# Altium schematic sheet Sheet1.SchDoc(Sheet1)
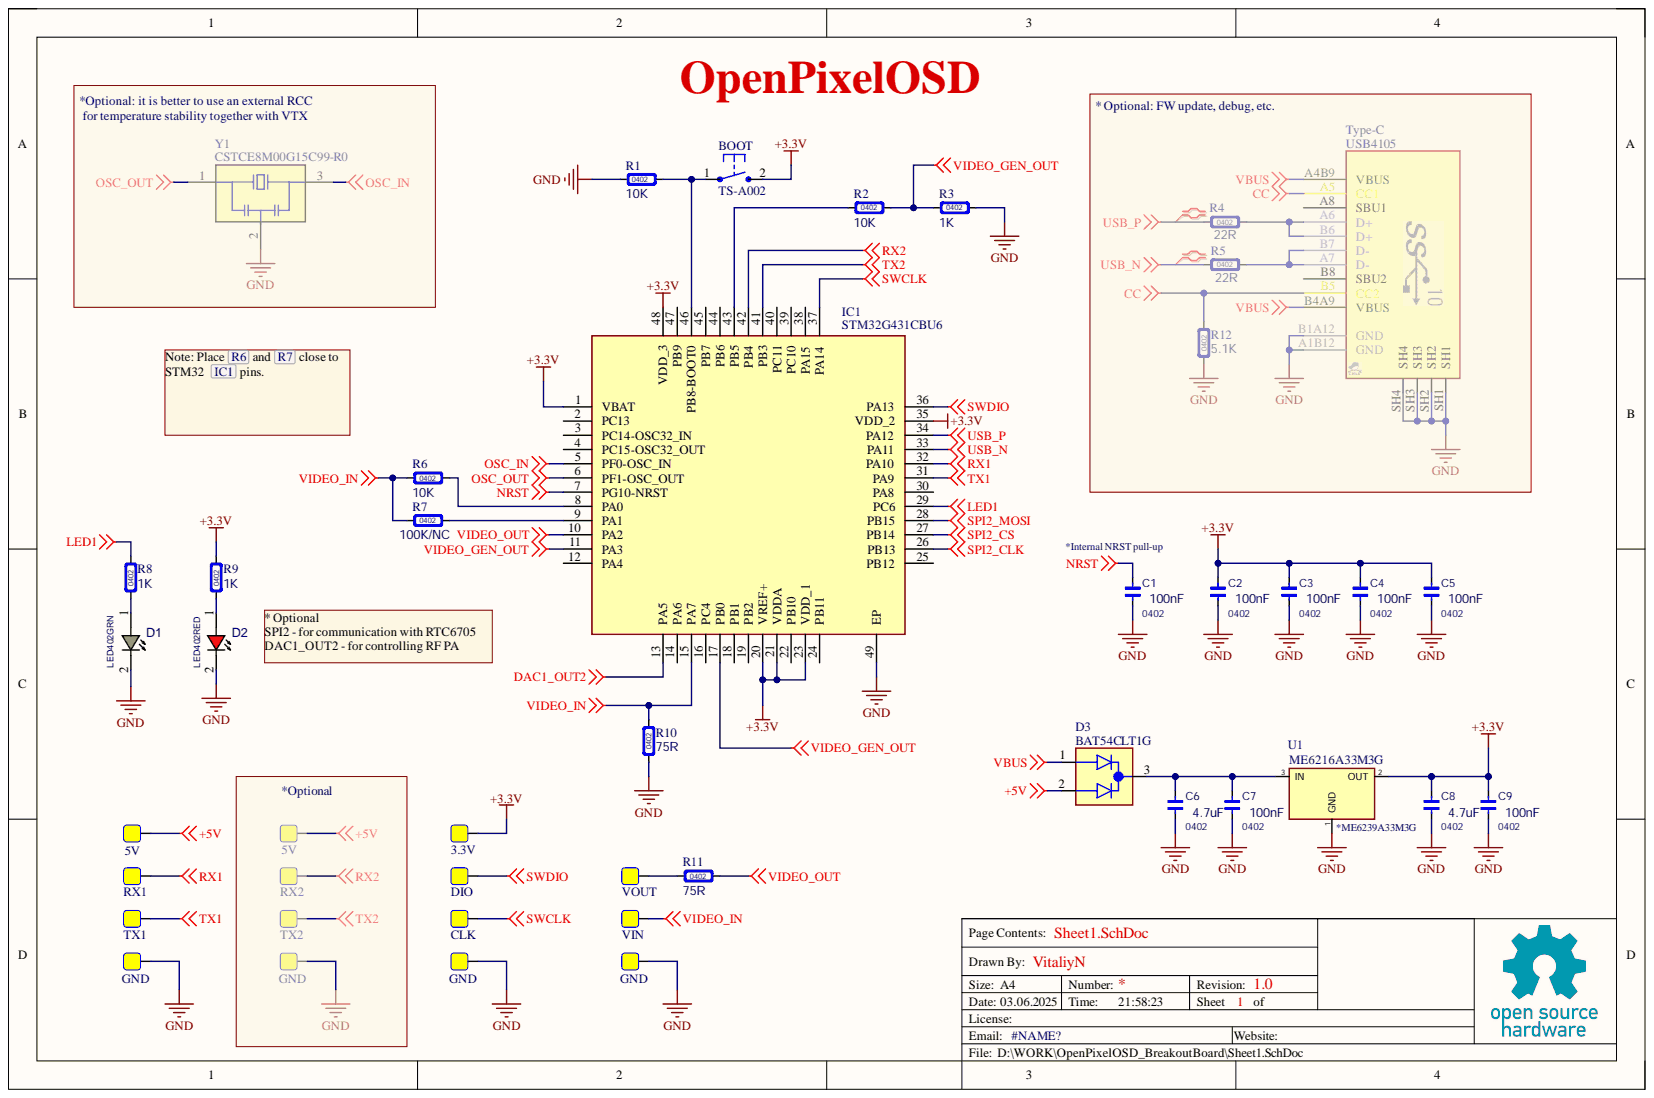
[diagram p0: Sheet1.SchDoc]

## Parts
3.3V  TP  [test_point_2mm]  (value not drawn)
5V  5V  [test_point_2mm]
5V1  5V  [test_point_2mm]
BOOT  TS-A002  [TST-A002_0.5MM_BOSS]
C1  value 100nF  Size: 0402  [CAP_0402]  (hidden comment: C0402)
C2  value 100nF  Size: 0402  [CAP_0402]  (hidden comment: C0402)
C3  value 100nF  Size: 0402  [CAP_0402]  (hidden comment: C0402)
C4  value 100nF  Size: 0402  [CAP_0402]  (hidden comment: C0402)
C5  value 100nF  Size: 0402  [CAP_0402]  (hidden comment: C0402)
C6  value 4.7uF  Size: 0402  [CAP_0402]  (hidden comment: C0402)
C7  value 100nF  Size: 0402  [CAP_0402]  (hidden comment: C0402)
C8  value 4.7uF  Size: 0402  [CAP_0402]  (hidden comment: C0402)
C9  value 100nF  Size: 0402  [CAP_0402]  (hidden comment: C0402)
CLK  TP  [test_point_2mm]  (value not drawn)
D1  LED402GRN  [LED0402GRN]
D2  LED402RED  [LED0402RED]
D3  BAT54CLT1G  [SOT23-3]
DIO  TP  [test_point_2mm]  (value not drawn)
GND1  GND  [test_point_2mm]
GND2  GND  [test_point_2mm]
GND3  GND  [test_point_2mm]
GND4  GND  [test_point_2mm]
IC1  STM32G431CBU6  [QFN48P700X700X65-49N-D]
R1  value 10K  Type: 0402  [RES_0402]  (hidden comment: R0402)
R2  value 10K  Type: 0402  [RES_0402]  (hidden comment: R0402)
R3  value 1K  Type: 0402  [RES_0402]  (hidden comment: R0402)
R4  R0402  value 22R  Type: 0402  [RES_0402]
R5  R0402  value 22R  Type: 0402  [RES_0402]
R6  value 10K  Type: 0402  [RES_0402]  (hidden comment: R0402)
R7  Type: 0402  [RES_0402]  (hidden comment: R0402)
R8  value 1K  Type: 0402  [RES_0402]  (hidden comment: R0402)
R9  value 1K  Type: 0402  [RES_0402]  (hidden comment: R0402)
R10  R0402  value 75R  Type: 0402  [RES_0402]
R11  R0402  value 75R  Type: 0402  [RES_0402]
R12  value 5.1K  Type: 0402  [RES_0402]  (hidden comment: R0402)
RX1  TP  [test_point_2mm]  (value not drawn)
RX2  TP  [test_point_2mm]  (value not drawn)
TX1  TP  [test_point_2mm]  (value not drawn)
TX2  TP  [test_point_2mm]  (value not drawn)
Type-C  USB4105  [USBC_SMD_16_USB4105]
U1  ME6216A33M3G  [SOT23-3]
VIN  TP  [test_point_2mm]  (value not drawn)
VOUT  TP  [test_point_2mm]  (value not drawn)
Y1  CSTCE8M00G15C99-R0  [CSTCE8M00G15C99]

## Nets
+3.3V: 3.3V.1 BOOT.2 C2.1 C3.1 C4.1 C5.1 C8.1 C9.1 IC1.1(VBAT) IC1.20(VREF+) IC1.21(VDDA) IC1.23(VDD_1) IC1.35(VDD_2) IC1.48(VDD_3) R9.2 U1.2
+5V: 5V.1 5V1.1 D3.2
CC: R12.1 Type-C.A5(CC1) Type-C.B5(CC2)
GND: C1.2 C2.2 C3.2 C4.2 C5.2 C6.2 C7.2 C8.2 C9.2 D1.2 D2.2 GND1.1 GND2.1 GND3.1 GND4.1 IC1.49(EP) R1.2 R3.1 R10.2 R12.2 Type-C.A1B12(GND) Type-C.B1A12(GND) Type-C.SH1 Type-C.SH2 Type-C.SH3 Type-C.SH4 U1.1 Y1.2
LED1: IC1.29(PC6) R8.2
NRST: C1.1 IC1.7(PG10-NRST)
OSC_IN: IC1.5(PF0-OSC_IN) Y1.3
OSC_OUT: IC1.6(PF1-OSC_OUT) Y1.1
RX1: IC1.32(PA10) RX1.1
RX2: IC1.42(PB4) RX2.1
SWCLK: CLK.1 IC1.37(PA14)
SWDIO: DIO.1 IC1.36(PA13)
TX1: IC1.31(PA9) TX1.1
TX2: IC1.41(PB3) TX2.1
USB_N: IC1.33(PA11) R5.2
USB_P: IC1.34(PA12) R4.2
VBUS: D3.1 Type-C.A4B9(VBUS) Type-C.B4A9(VBUS)
VIDEO_GEN_OUT: IC1.11(PA3) IC1.17(PB0) R2.1 R3.2
VIDEO_IN: IC1.15(PA7) R6.2 R7.2 R10.1 VIN.1
VIDEO_OUT: IC1.10(PA2) R11.2
NetBOOT_1: BOOT.1 IC1.46(PB8-BOOT0) R1.1
NetC6_1: C6.1 C7.1 D3.3 U1.3
NetD1_1: D1.1 R8.1
NetD2_1: D2.1 R9.1
NetIC1_8: IC1.8(PA0) R6.1
NetIC1_9: IC1.9(PA1) R7.1
NetIC1_43: IC1.43(PB5) R2.2
NetR4_1: R4.1 Type-C.A6(D+) Type-C.B6(D+)
NetR5_1: R5.1 Type-C.A7(D-) Type-C.B7(D-)
NetR11_1: R11.1 VOUT.1

Not connected: IC1 2(PC13) 3(PC14-OSC32_IN) 4(PC15-OSC32_OUT) 12(PA4) 13(PA5) 14(PA6) 16(PC4) 18(PB1) 19(PB2) 22(PB10) 24(PB11) 25(PB12) 26(PB13) 27(PB14) 28(PB15) 30(PA8) 38(PA15) 39(PC10) 40(PC11) 44(PB6) 45(PB7) 47(PB9); Type-C A8(SBU1) B8(SBU2)

## Library data of the parts
3.3V 5V 5V1 CLK DIO GND1-GND4 RX1 RX2 TX1 TX2 VIN VOUT = test_point_2mm: test point  Not incluted to BOM; Component Kind: Standard; Library Name: SCH_Passive.SchLib; Price 1: 0; Price 1000: 0
BOOT = TS-A002: SPST Pushbutton Right Angle Mount [2 x 4 x 3.5] TST2435; MF: Zaosne; Availability: Unavailable; Component Kind: Standard; Library Name: SCH_IntegratedCircuit.SchLib; MPN: TS-A002; Package: Package Analog Devices; Price: None; SnapEDA_Link: https://www.snapeda.com/parts/TS-A002/Zaosne/view-part/3625795/?ref=snap
C1-C5 C9 = Cap_0402: Capacitor X5R; Voltage: ?V; Component Kind: Standard; Library Name: SCH_Passive.SchLib
C6 C8 = Cap_0402: Capacitor X5R; Value: 4.7uF; Voltage: ?V; Component Kind: Standard; Library Name: SCH_Passive.SchLib
C7 = Cap_0402: Capacitor X5R; Value: 100nF; Voltage: ?V; Component Kind: Standard; Library Name: SCH_Passive.SchLib
D1 = LED_SMD_0402_GRN: LED, SMD, 0402, GREEN; MPN: SZYY0402YG; DESC: DESCRIPTION; MANF: Wurth Electronics; MANF_P#: 150040GS73240, HSMG-C280; PARAM: 20mA; PARAM2: 2.2V; ASSEMBLY: ASSEMBLY; Component Kind: Standard; Library Name: led(diode).SchLib; PRICE: 0.3$; VALUE: LED402GRN
D2 = LED_SMD_0402_RED: LED, SMD, 0402, RED; MPN: SZYY0402R; DESC: DESCRIPTION; MANF: Wurth Electronics, VCC; MANF_P#: 150040RS73240, VAOL-S4RP4; PARAM: 20mA, 640nm; PARAM2: 1.8~2.5V; ASSEMBLY: ASSEMBLY; Component Kind: Standard; Library Name: led(diode).SchLib; PRICE: 0.3$; VALUE: LED402RED
D3 = BAT54CLT1G: Diode Array 1 Pair Common Cathode Schottky 30 V 200mA (DC) Surface Mount TO-236-3, SC-59, SOT-23-3; MF: ON Semiconductor; Check_prices: https://www.snapeda.com/parts/BAT54CLT1G/Onsemi/view-part/?ref=eda; Component Kind: Standard; Library Name: BAT54CLT1G.SchLib; MP: BAT54CLT1G; Package: SOT-23-3 ON Semiconductor; SnapEDA_Link: https://www.snapeda.com/parts/BAT54CLT1G/Onsemi/view-part/?ref=snap
IC1 = STM32G431CBU6: Integrated Circuit; Manufacturer_Name: STMicroelectronics; Arrow Part Number: STM32G431CBU6; Arrow Price/Stock: https://www.arrow.com/en/products/stm32g431cbu6/stmicroelectronics?utm_currency=USD&region=nac; Component Kind: Standard; Datasheet Link: https://www.st.com/resource/en/datasheet/stm32g431cb.pdf; Height: 0.65mm; Library Name: STM32G431CBU6.SchLib; Manufacturer_Part_Number: STM32G431CBU6; Mouser Part Number: 511-STM32G431CBU6; Mouser Price/Stock: https://www.mouser.co.uk/ProductDetail/STMicroelectronics/STM32G431CBU6?qs=T3oQrply3y%252B0hTuSHQ7oqA%3D%3D
R1-R6 R10 R11 = Res_0402: Resistor; Specification: ±5%; Component Kind: Standard; Library Name: SCH_Passive.SchLib
R7 = Res_0402: Resistor; Specification: ±5%; Value: 100K; Component Kind: Standard; Library Name: SCH_Passive.SchLib
R8 R9 = Res_0402: Resistor; MPN: RC0402JR-071KL; Specification: ±5%; Component Kind: Standard; Library Name: SCH_Passive.SchLib
R12 = Res_0402: Resistor; MPN: RC0402FR-075K1L; Specification: ±5%; Component Kind: Standard; Library Name: SCH_Passive.SchLib
Type-C = CONN_USBC_SMD_16_USB4105: CONN, USB, Type C, USB 2.0, Right-Angle, SMD; MANF: GCT; MANF_P#: USB4105-GF-A; PARAM: 5A; Component Kind: Standard; Library Name: conn_usbc.SchLib; Price: 1.5$; Value: USB4105
U1 = LDO: Linear Voltage Regulators; Manufacturer: Shikues; mpn: 78L05S; Value: 5V; Component Kind: Standard; Library Name: SCH_Active.SchLib; Link: https://lcsc.com/product-detail/Linear-Voltage-Regulators_Shikues-78L05S_C122620.html; Type: Fixed
Y1 = CSTCE8M00G15C99-R0: 8MHz Ceramic Resonator Built in Capacitor 33pF±0.13% -40Â°C ~ 125Â°C Surface Mount; Manufacturer: Murata Electronics; Mpn: CSTNE8M00G520000R0; Availability: Not in stock; Check_prices: https://www.snapeda.com/parts/CSTCE8M00G15C99-R0/Murata%20Electronics%20North%20America/view-part/217608/?ref=eda; Component Kind: Standard; Library Name: SCH_Oscillator.SchLib; Link: https://www.lcsc.com/product-detail/Ceramic-Resonators_Murata-Electronics-CSTNE8M00G520000R0_C907975.html; MF: Murata Electronics; MP: CSTCE8M00G15C99-R0; Package: 3213-3P; Price: 0.1918; Price 1: 0.1918; Price 1000: 0.1074; SnapEDA_Link: https://www.snapeda.com/parts/CSTCE8M00G15C99-R0/Murata%20Electronics%20North%20America/view-part/217608/?ref=snap; Value: 8MHz
